# Altium schematic sheet tx_mini_buck.SchDoc(tx_mini_buck)
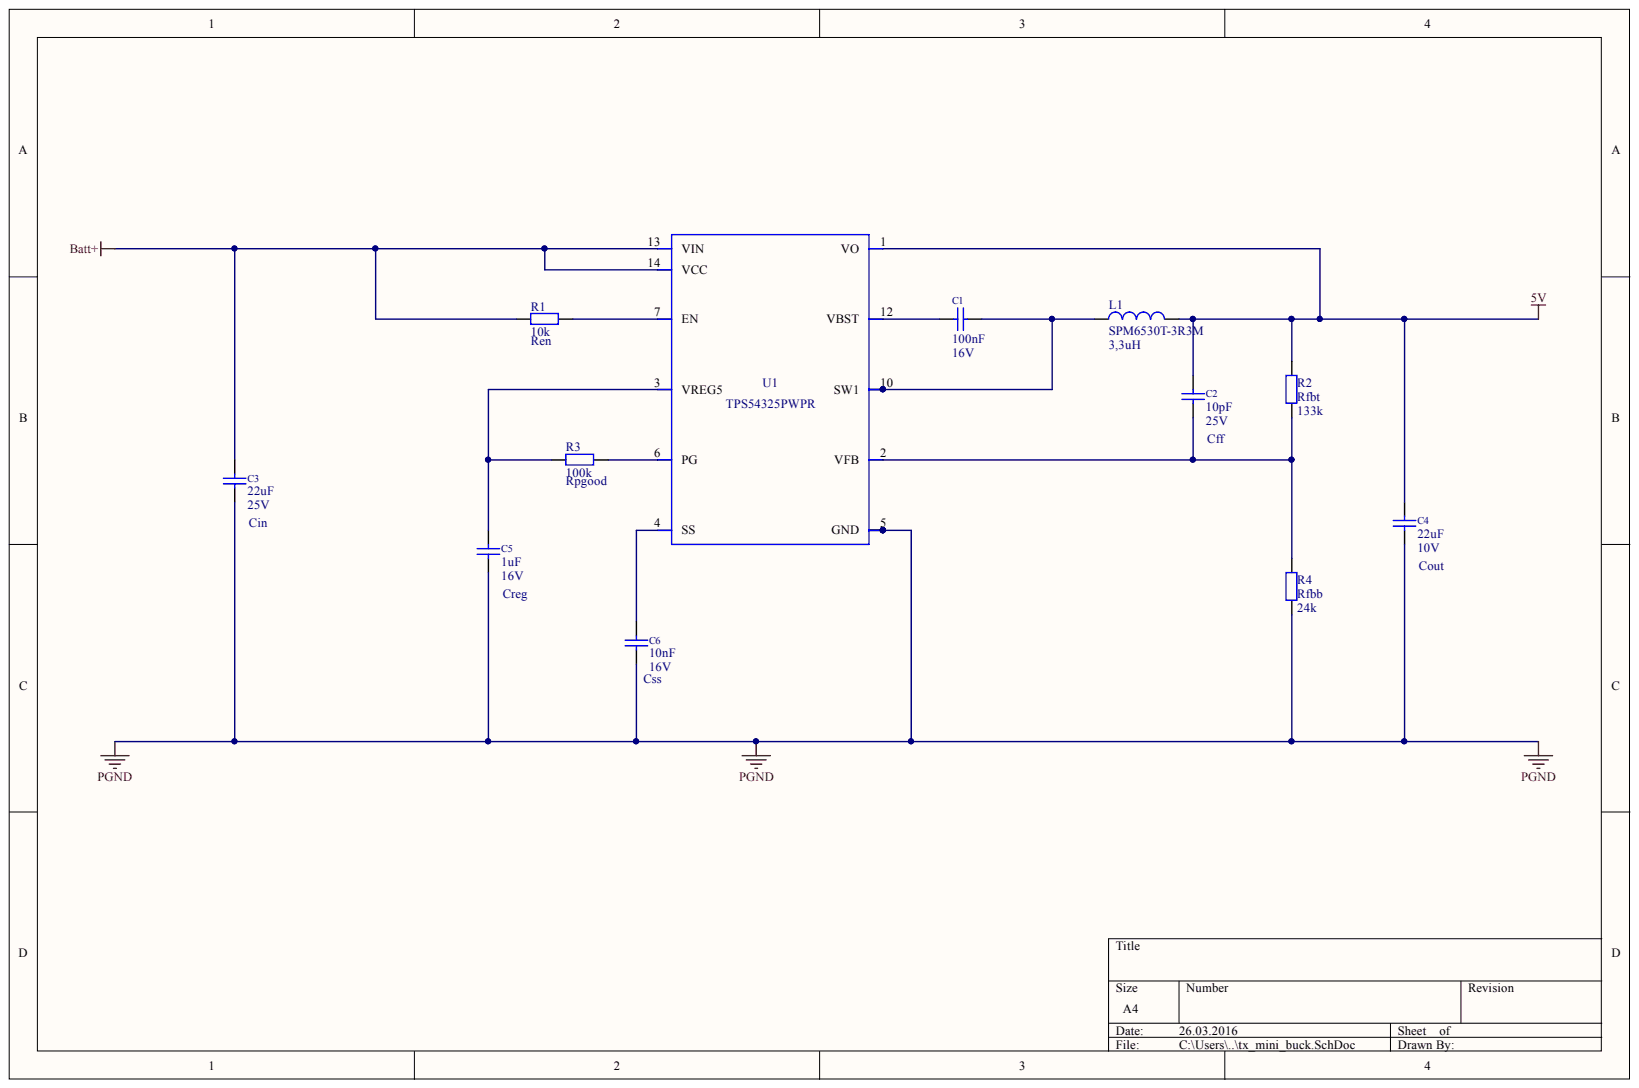
[diagram p0: tx_mini_buck.SchDoc]

## Parts
C1  100nF  Voltage: 16V  [C0402]
C2  10pF  Bezeichnung: Cff  Voltage: 25V  [C0402]
C3  22uF  Bezeichnung: Cin  Voltage: 25V  [C1210]
C4  22uF  Bezeichnung: Cout  Voltage: 10V  [C1206]
C5  1uF  Bezeichnung: Creg  Voltage: 16V  [C0603]
C6  10nF  Bezeichnung: Css  Voltage: 16V  [C0402]
L1  Herst.Bez.: SPM6530T-3R3M  Inductance: 3,3uH  [SPM6530]  (hidden comment: Inductance)
R1  R  value 10k  Bezeichnung: Ren  [RES0402]
R2  R  value 133k  Bezeichnung: Rfbt  [RES0402]
R3  R  value 100k  Bezeichnung: Rpgood  [RES0402]
R4  R  value 24k  Bezeichnung: Rfbb  [RES0603]
U1  TPS54225PWP  [R-PDSO-G14]  (value not drawn)

## Nets
5V: C2.1 C4.1 L1.2 R2.2 U1.1(VO)
BATT+: C3.1 R1.1 U1.13(VIN) U1.14(VCC)
PGND: C3.2 C4.2 C5.2 C6.2 R4.1 U1.5(GND) U1.8 U1.9 U1.15
NetC1_1: C1.1 U1.12(VBST)
NetC1_2: C1.2 L1.1 U1.10(SW1) U1.11
NetC2_2: C2.2 R2.1 R4.2 U1.2(VFB)
NetC5_1: C5.1 R3.1 U1.3(VREG5)
NetC6_1: C6.1 U1.4(SS)
NetR1_2: R1.2 U1.7(EN)
NetR3_2: R3.2 U1.6(PG)

## Library data of the parts
C1 = C 100nF 0402: Capacitor; Component Kind: Standard; Value: 100nF; Library Name: Toni.IntLib
C2 = C: Capacitor; Herst.Bez.: GRM1555C1E100JA01D; Component Kind: Standard; Value: 10pF; Library Name: Toni.IntLib
C3 = C: Capacitor; Herst.Bez.: GRM32ER61E226KE15L; Component Kind: Standard; Value: 22uF; Library Name: Toni.IntLib
C4-C6 = C: Capacitor; Component Kind: Standard; Library Name: Toni.IntLib
  C4: Value: 22uF
  C5: Value: 1uF
  C6: Value: 10nF
L1 = L power: Power Inductance; Component Kind: Standard; Library Name: Toni.IntLib
R1 R3 = R: Resistor; Component Kind: Standard; Library Name: Toni.IntLib
R2 = R: Resistor; Herst.Bez.: CRCW0402133KFKED; Component Kind: Standard; Library Name: Toni.IntLib
R4 = R: Resistor; Herst.Bez.: RC0603FR-0724KL; Component Kind: Standard; Library Name: Toni.IntLib
U1 = TPS54225PWP: TPS54325PWPR; Manufacturer Name: Texas Instruments; Value: UNLISTED; Component Kind: Standard; Library Name: TI WEBENCH; Manufacturer Part Number: TPS54225PWP; PackageReference: TPS54325PWPR
